# Altium schematic sheet Sandshark 3D - MCU - ATSAM3X8C.SchDoc
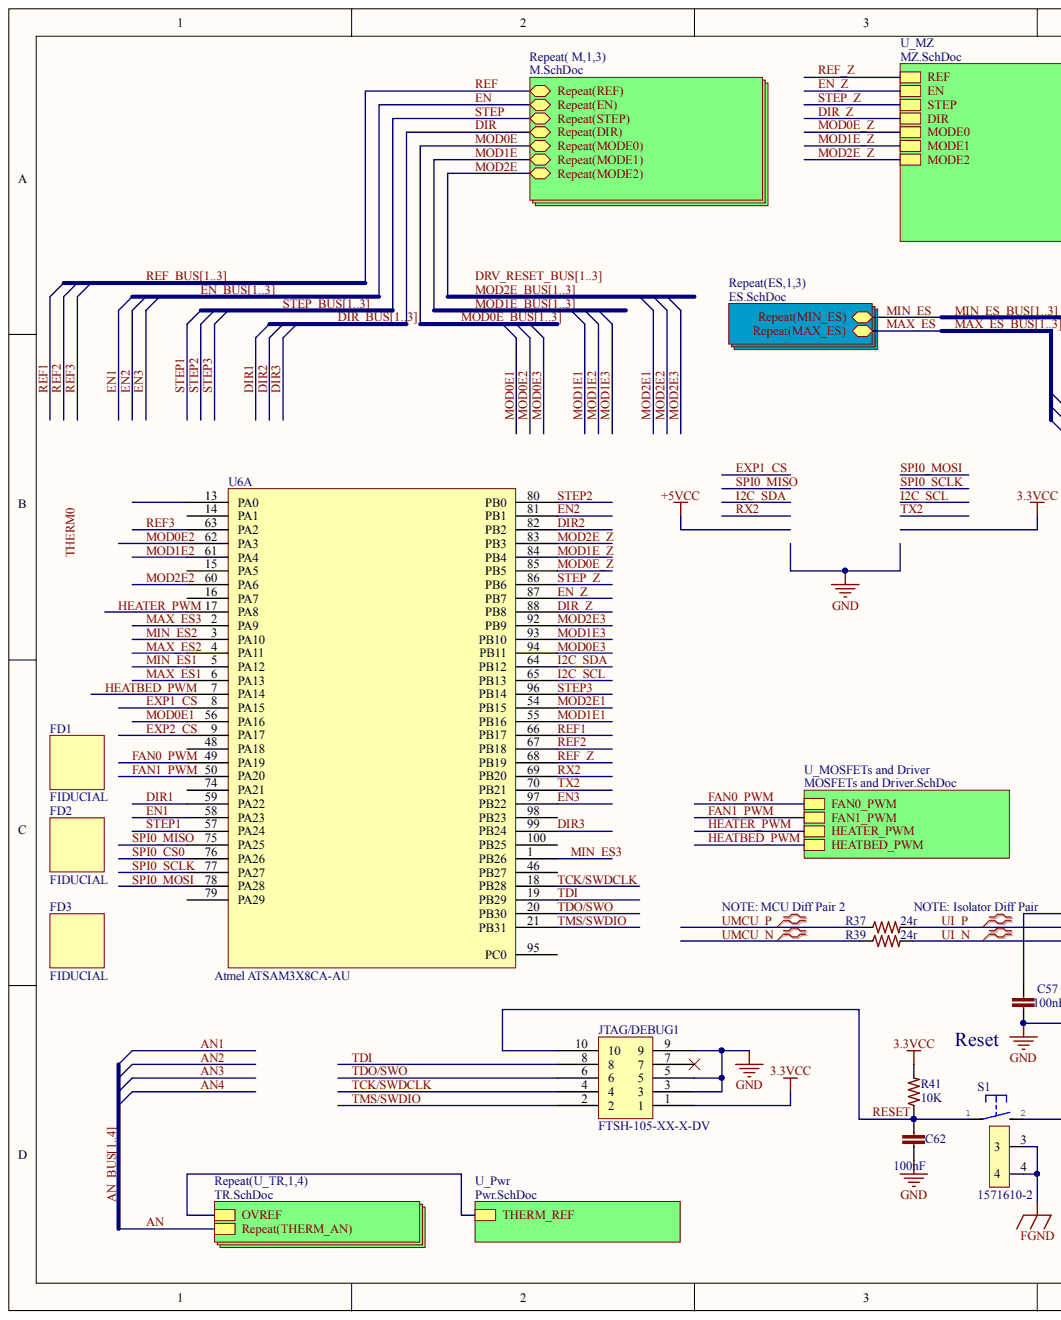
[diagram p0: Sandshark 3D - MCU - ATSAM3X8C.SchDoc, left]
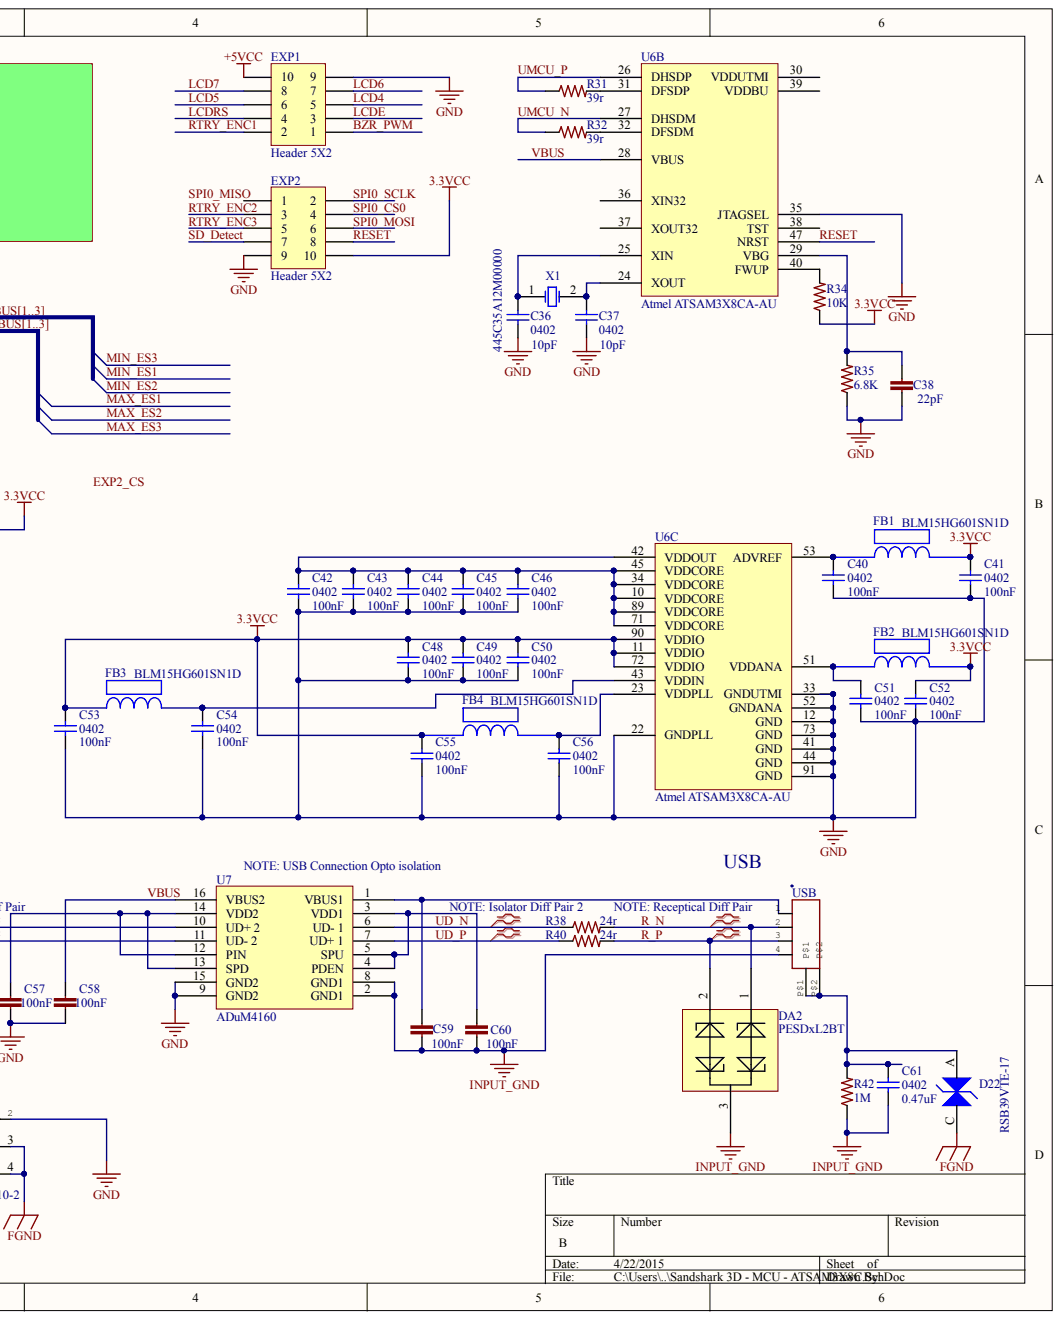
[diagram p1: Sandshark 3D - MCU - ATSAM3X8C.SchDoc, right]

## Parts
C36  0402  value 10pF  [C0402]
C37  0402  value 10pF  [C0402]
C38  22pF  [C0402]
C40  0402  value 100nF  [C0402]
C41  0402  value 100nF  [C0402]
C42  0402  value 100nF  [C0402]
C43  0402  value 100nF  [C0402]
C44  0402  value 100nF  [C0402]
C45  0402  value 100nF  [C0402]
C46  0402  value 100nF  [C0402]
C48  0402  value 100nF  [C0402]
C49  0402  value 100nF  [C0402]
C50  0402  value 100nF  [C0402]
C51  0402  value 100nF  [C0402]
C52  0402  value 100nF  [C0402]
C53  0402  value 100nF  [C0402]
C54  0402  value 100nF  [C0402]
C55  0402  value 100nF  [C0402]
C56  0402  value 100nF  [C0402]
C57  100nF  [C0402]
C58  100nF  [C0402]
C59  100nF  [C0402]
C60  100nF  [C0402]
C61  0402  value 0.47uF  [C0402]
C62  100nF  [C0402]
D22  RSB39VTE-17  [SOD323-R]
DA2  PESDxL2BT  [SOT95P230X110-3N]
EXP1  Header 5X2  [HDR2X5]
EXP2  Header 5X2  [HDR2X5]
FB1  BLM15HG601SN1D  [BLM18PG121SN1D]
FB2  BLM15HG601SN1D  [BLM18PG121SN1D]
FB3  BLM15HG601SN1D  [BLM18PG121SN1D]
FB4  BLM15HG601SN1D  [BLM18PG121SN1D]
FD1  FIDUCIAL  [FIDUCIAL-1X2]
FD2  FIDUCIAL  [FIDUCIAL-1X2]
FD3  FIDUCIAL  [FIDUCIAL-1X2]
JTAG/DEBUG1  FTSH-105-XX-X-DV  [FTSH-105-XX-X-DV]
R31  39r  [R0402]
R32  39r  [R0402]
R34  10K  [R0603]
R35  6.8K  [R0402]
R37  24r  [R0603]
R38  24r  [R0603]
R39  24r  [R0603]
R40  24r  [R0603]
R41  10K  [R0603]
R42  1M  [R0402]
S1  1571610-2  [B3F-31XX]
U6  Atmel ATSAM3X8CA-AU  Manufacture: Atmel  Manufacture Part #: ATSAM3X8CA-AU  [ATSAM3X8CA-AU TQFP100]  (parts A,B,C)
U7  ADuM4160  [ADUM4160BRWZ-RL]
USB  USB-B  [PN61729]  (value not drawn)
X1  445C35A12M00000  [445C35A12M00000]

## Nets
3.3VCC: C41.2 C48.2 C49.2 C50.2 C52.2 C53.2 C55.2 EXP2.10 FB1.2 FB2.2 FB3.1 FB4.1 JTAG/DEBUG1.1 R34.1 R41.2 U6.11(VDDIO) U6.72(VDDIO) U6.90(VDDIO)
+5VCC: EXP1.10
FGND: D22.C S1.3 S1.4
GND: C36.1 C37.1 C38.2 C40.1 C41.1 C42.1 C43.1 C44.1 C45.1 C46.1 C48.1 C49.1 C50.1 C51.1 C52.1 C53.1 C54.1 C55.1 C56.1 C57.2 C58.2 C62.2 EXP1.9 EXP2.9 JTAG/DEBUG1.3 JTAG/DEBUG1.5 JTAG/DEBUG1.9 R35.1 S1.2 U6.12(GND) U6.22(GNDPLL) U6.33(GNDUTMI) U6.35(JTAGSEL) U6.41(GND) U6.44(GND) U6.52(GNDANA) U6.73(GND) U6.91(GND) U7.9(GND2) U7.15(GND2)
INPUT_GND: C59.2 C60.2 C61.1 DA2.3 R42.1 U7.2(GND1) U7.8(GND1) USB.4
BZR_PWM: EXP1.1
DIR1: U6.59(PA22)
DIR2: U6.82(PB2)
DIR3: U6.99(PB24)
DIR_Z: U6.88(PB8)
EN1: U6.58(PA23)
EN2: U6.81(PB1)
EN3: U6.97(PB22)
EN_Z: U6.87(PB7)
EXP1_CS: U6.8(PA15)
EXP2_CS: U6.9(PA17)
FAN0_PWM: U6.49(PA19)
FAN1_PWM: U6.50(PA20)
HEATBED_PWM: U6.7(PA14)
HEATER_PWM: U6.17(PA8)
I2C_SCL: U6.65(PB13)
I2C_SDA: U6.64(PB12)
LCD4: EXP1.5
LCD5: EXP1.6
LCD6: EXP1.7
LCD7: EXP1.8
LCDE: EXP1.3
LCDRS: EXP1.4
MAX_ES1: U6.6(PA13)
MAX_ES2: U6.4(PA11)
MAX_ES3: U6.2(PA9)
MIN_ES1: U6.5(PA12)
MIN_ES2: U6.3(PA10)
MIN_ES3: U6.1(PB26)
MOD0E1: U6.56(PA16)
MOD0E2: U6.62(PA3)
MOD0E3: U6.94(PB11)
MOD0E_Z: U6.85(PB5)
MOD1E1: U6.55(PB16)
MOD1E2: U6.61(PA4)
MOD1E3: U6.93(PB10)
MOD1E_Z: U6.84(PB4)
MOD2E1: U6.54(PB15)
MOD2E2: U6.60(PA6)
MOD2E3: U6.92(PB9)
MOD2E_Z: U6.83(PB3)
R_N: DA2.1 R38.2 USB.2
R_P: DA2.2 R40.2 USB.3
REF1: U6.66(PB17)
REF2: U6.67(PB18)
REF3: U6.63(PA2)
REF_Z: U6.68(PB19)
RESET: C62.1 EXP2.8 JTAG/DEBUG1.10 R41.1 S1.1 U6.47(NRST)
RTRY_ENC1: EXP1.2
RTRY_ENC2: EXP2.3
RTRY_ENC3: EXP2.5
RX2: U6.69(PB20)
SD_DETECT: EXP2.7
SPI0_CS0: EXP2.4 U6.76(PA26)
SPI0_MISO: U6.75(PA25)
SPI0_MOSI: EXP2.6 U6.78(PA28)
SPI0_SCLK: EXP2.2 U6.77(PA27)
STEP1: U6.57(PA24)
STEP2: U6.80(PB0)
STEP3: U6.96(PB14)
STEP_Z: U6.86(PB6)
TCK/SWDCLK: JTAG/DEBUG1.4 U6.18(PB28)
TDI: JTAG/DEBUG1.8 U6.19(PB29)
TDO/SWO: JTAG/DEBUG1.6 U6.20(PB30)
TMS/SWDIO: JTAG/DEBUG1.2 U6.21(PB31)
TX2: U6.70(PB21)
UD_N: R38.1 U7.6(UD-_1)
UD_P: R40.1 U7.7(UD+_1)
UI_N: R39.2 U7.11(UD-_2)
UI_P: R37.2 U7.10(UD+_2)
UMCU_N: R32.1 R39.1 U6.27(DHSDM)
UMCU_P: R31.1 R37.1 U6.26(DHSDP)
VBUS: C58.1 U6.28(VBUS) U7.16(VBUS2)
NetC36_2: C36.2 U6.25(XIN) X1.1
NetC37_2: C37.2 U6.24(XOUT) X1.2
NetC38_1: C38.1 R35.2 U6.29(VBG)
NetC40_2: C40.2 FB1.1 U6.53(ADVREF)
NetC42_2: C42.2 C43.2 C44.2 C45.2 C46.2 U6.10(VDDCORE) U6.34(VDDCORE) U6.42(VDDOUT) U6.45(VDDCORE) U6.71(VDDCORE) U6.89(VDDCORE)
NetC51_2: C51.2 FB2.1 U6.51(VDDANA)
NetC54_2: C54.2 FB3.2 U6.43(VDDIN)
NetC56_2: C56.2 FB4.2 U6.23(VDDPLL)
NetC57_1: C57.1 U7.12(PIN) U7.13(SPD) U7.14(VDD2)
NetC59_1: C59.1 U7.1(VBUS1) USB.1
NetC60_1: C60.1 U7.3(VDD1) U7.4(PDEN) U7.5(SPU)
NetC61_2: C61.2 D22.A R42.2 USB.P$1 USB.P$2
NetEXP2_1: EXP2.1
NetJTAG/DEBUG1_7: JTAG/DEBUG1.7
NetR31_2: R31.2 U6.31(DFSDP)
NetR32_2: R32.2 U6.32(DFSDM)
NetR34_2: R34.2 U6.40(FWUP)
NetU6_13: U6.13(PA0)
NetU6_14: U6.14(PA1)
NetU6_15: U6.15(PA5)
NetU6_16: U6.16(PA7)
NetU6_30: U6.30(VDDUTMI)
NetU6_36: U6.36(XIN32)
NetU6_37: U6.37(XOUT32)
NetU6_38: U6.38(TST)
NetU6_39: U6.39(VDDBU)
NetU6_46: U6.46(PB27)
NetU6_48: U6.48(PA18)
NetU6_74: U6.74(PA21)
NetU6_79: U6.79(PA29)
NetU6_95: U6.95(PC0)
NetU6_98: U6.98(PB23)
NetU6_100: U6.100(PB25)
AN1:
AN2:
AN3:
AN4:
AN_BUS1:  [also labelled AN_BUS]
AN_BUS2:  [also labelled AN_BUS]
AN_BUS3:  [also labelled AN_BUS]
AN_BUS4:  [also labelled AN_BUS]
DIR_BUS1:  [also labelled DIR_BUS]
DIR_BUS2:  [also labelled DIR_BUS]
DIR_BUS3:  [also labelled DIR_BUS]
DRV_RESET_BUS1:  [also labelled DRV_RESET_BUS]
DRV_RESET_BUS2:  [also labelled DRV_RESET_BUS]
DRV_RESET_BUS3:  [also labelled DRV_RESET_BUS]
EN_BUS1:  [also labelled EN_BUS]
EN_BUS2:  [also labelled EN_BUS]
EN_BUS3:  [also labelled EN_BUS]
MAX_ES_BUS1:  [also labelled MAX_ES_BUS]
MAX_ES_BUS2:  [also labelled MAX_ES_BUS]
MAX_ES_BUS3:  [also labelled MAX_ES_BUS]
MIN_ES_BUS1:  [also labelled MIN_ES_BUS]
MIN_ES_BUS2:  [also labelled MIN_ES_BUS]
MIN_ES_BUS3:  [also labelled MIN_ES_BUS]
MOD0E_BUS1:  [also labelled MOD0E_BUS]
MOD0E_BUS2:  [also labelled MOD0E_BUS]
MOD0E_BUS3:  [also labelled MOD0E_BUS]
MOD1E_BUS1:  [also labelled MOD1E_BUS]
MOD1E_BUS2:  [also labelled MOD1E_BUS]
MOD1E_BUS3:  [also labelled MOD1E_BUS]
MOD2E_BUS1:  [also labelled MOD2E_BUS]
MOD2E_BUS2:  [also labelled MOD2E_BUS]
MOD2E_BUS3:  [also labelled MOD2E_BUS]
REF_BUS1:  [also labelled REF_BUS]
REF_BUS2:  [also labelled REF_BUS]
REF_BUS3:  [also labelled REF_BUS]
STEP_BUS1:  [also labelled STEP_BUS]
STEP_BUS2:  [also labelled STEP_BUS]
STEP_BUS3:  [also labelled STEP_BUS]
THERM0:
THERM_REF:

## Library data of the parts
C36 C37 = CL05C100JB5NNNC: CAP CER 10PF 50V 5% NP0 0402; MFR: Samsung; Tolerance: 10%; Voltage: 50VDC; Component Kind: Standard; Component Type: Chip Capacitor; Datasheet: http://www.samsungsem.com/kr/front/downloadcms.do?path=/kr/support/product-search/mlcc/__icsFiles/afieldfile/2014/11/05&fileName=S_CL05C100JB5NNNC.pdf; Height: 0.55mm; LatestRevisionDate: 10 - Dec - 2014; LatestRevisionNote: Ultimachine Footprint Added; Library Name: Tigershark3D.SchLib; MFR Part #: CL05C100JB5NNNC; Mounting Type: SMT 0402; PackageReference: 0402; Part Description: CAP CER 10PF 50V 5% NP0 0402; Published: 10 - Dec - 2014; Signal Integrity: Cap Semi; Simulation: CAP; Publisher: Ultimachine
C38 = 04025A220JAT2A: CAP CER 0402 22pF 50V 5% C0G; LastRevisionNote: ImportComponents; Component Kind: Standard; LastRevisionDate: 23-Apr-2014; Library Name: 3Delight.SCHLIB; ManufacturerPartNumber: 04025A220JAT2A; Published: 23-Apr-2014; Signal Integrity: Model Name; Simulation: Model Name; VALUE: 22pF; Publisher: UltiMachine
C40-C46 C48-C56 = GRM155R71C104KA88D: CAP CER 0.1UF (100nF) 16V 10% X7R 0402; MFR: Murata; Part Description: CAP CER 0.1UF 16V 10% X7R 0402; Component Kind: Standard; Component Type: Chip Capacitor; Datasheet: http://psearch.murata.com/capacitor/product/GRM155R71C104KA88%23.pdf; Height: 0.5 ±0.05mm; LatestRevisionDate: 10 - Dec - 2014; LatestRevisionNote: Ultimachine Footprint Added; Library Name: Tigershark3D.SchLib; MFR Part #: GRM155R71C104KA88D; Mounting Type: SMT 0402; PackageReference: 0402; Published: 10 - Dec - 2014; Signal Integrity: Cap Semi; Simulation: CAP; Tolerance: 10%; Voltage: 16v; Publisher: Ultimachine
C57-C60 C62 = GRM155R71C104KA88D: CAP CER 0402 100nF 16V 10% X7R; LastRevisionNote: ImportComponents; Component Kind: Standard; LastRevisionDate: 23-Apr-2014; Library Name: 3Delight.SCHLIB; ManufacturerPartNumber: GRM155R71C104KA88D; Published: 23-Apr-2014; Signal Integrity: Model Name; Simulation: Model Name; VALUE: 100nF; Publisher: UltiMachine
C61 = GRM155R60J474KE19D: 0.47uF 6.3v C0402 - GRM155R60J474KE19D; MFR: Murata; Part Description: CAP CER 0.47UF 6.3V 10% X5R 0402; Tolerance: 10%; Voltage: 6.3VDC; Component Kind: Standard; Component Type: Chip Capacitor; Datasheet: http://search.murata.co.jp/Ceramy/image/img/A01X/G101/ENG/GRM155R60J474KE19-01.pdf; Height: 0.5 ±0.05mm; LatestRevisionDate: 10 - Dec - 2014; LatestRevisionNote: Ultimachine Footprint Added; Library Name: Tigershark3D.SchLib; MFR Part #: GRM155R60J474KE19D; Mounting Type: SMT 0402; PackageReference: 0402; Published: 10 - Dec - 2014; Signal Integrity: Cap Semi; Simulation: CAP; Publisher: Ultimachine
D22 = RSB39VTE-17: DIODE TVS; Component Kind: Standard; Library Name: Tigershark3D.SchLib
DA2 = PESDxL2BT: PESDxL2BT TVS Diode Array 2x; Component Kind: Standard; Library Name: Tigershark3D.SchLib
EXP1 EXP2 = Header 5X2: Header, 5-Pin, Dual row; Component Kind: Standard; LatestRevisionDate: 17-Jul-2002; LatestRevisionNote: Re-released for DXP Platform.; Library Name: Miscellaneous Connectors.IntLib; Signal Integrity: Connector; Publisher: Altium Limited
JTAG/DEBUG1 = ARM Cortex JTAG-DEBUG Header: Component Kind: Standard; Library Name: Adams Altium Library.SchLib
R31 R32 = ERJ-2RKF39R0X: RES SMD 39 OHM 1% 1/10W 0402; Manufacturer: Panasonic; LastRevisionNote: ImportComponents; Component Kind: Standard; Height: 0.4mm; LastRevisionDate: 23-Apr-2014; Library Name: Tigershark3D.SchLib; ManufacturerPartNumber: ERJ-2RKF39R0X; Published: 23-Apr-2014; Signal Integrity: Model Name; Simulation: Model Name; VALUE: 39r; Publisher: UltiMachine
R34 R41 = ERJ-3EKF1002V: RES 0603 10K 100mW 1%; LastRevisionNote: ImportComponents; Component Kind: Standard; LastRevisionDate: 23-Apr-2014; ManufacturerPartNumber: ERJ-3EKF1002V; Published: 23-Apr-2014; Signal Integrity: Model Name; Simulation: Model Name; VALUE: 10K; Publisher: UltiMachine
  R34: Library Name: Tigershark3D.SchLib
  R41: Library Name: 3Delight.SCHLIB
R35 = CRCW04026K80FKED: RES SMD 6.8K OHM 1% 1/16W 0402; Manufacturer: Vishay Dale; LastRevisionNote: ImportComponents; Component Kind: Standard; Height: 0.4mm; LastRevisionDate: 23-Apr-2014; Library Name: Tigershark3D.SchLib; ManufacturerPartNumber: CRCW04026K80FKED; Published: 23-Apr-2014; Signal Integrity: Model Name; Simulation: Model Name; VALUE: 6.8K; Publisher: UltiMachine
R37-R40 = RC1608F240CS: RES SMD 24 OHM 1% 1/10W 0603; Manufacturer: Samsung; ManufacturerPartNumber: RC0603FR-07100KL; LastRevisionNote: ImportComponents; Component Kind: Standard; LastRevisionDate: 23-Apr-2014; Library Name: Tigershark3D.SchLib; PDF: http://www.samsungsem.com/global/support/library/product-catalog/__icsFiles/afieldfile/2015/01/12/CHIP_RESISTOR_150112_1.pdf; Published: 23-Apr-2014; Signal Integrity: Model Name; Simulation: Model Name; VALUE: 24r; Publisher: UltiMachine
R42 = ERJ2GEJ105X: RES 0402 1M 100mW 5%; LastRevisionNote: ImportComponents; Component Kind: Standard; LastRevisionDate: 23-Apr-2014; Library Name: Tigershark3D.SchLib; ManufacturerPartNumber: ERJ2GEJ105X; Published: 23-Apr-2014; Signal Integrity: Model Name; Simulation: Model Name; VALUE: 1M; Publisher: UltiMachine
S1 = 1571610-2_1: SWTCH Momentary Washable; Manufacturer: TE; Manufacturer: Omron; LastRevisionNote: Import; Component Kind: Standard; ComponentLink1Description: Datasheet for B3F-31XX; ComponentLink1URL: http://www.te.com/commerce/DocumentDelivery/DDEController?Action=srchrtrv&DocNm=1571610&DocType=Customer+Drawing&DocLang=English; LastRevisionDate: 23-Apr-2014; ManufacturerPartNumber: 1571610-2; Published: 23-Apr-2014; Supplier 1: Mouser; Supplier Part Number 1: 506-1571610-2; Library Name: ZMBo Schematic Library.SchLib; Publisher: UltiMachine
U6 = ATML-ATSAM3X8CA-AU-LQFP-100: Atmel ARM Cortex M3 MCU ATSAM3X8CA-AU; Component Kind: Standard; Datasheet: http://www.atmel.com/Images/doc11057s.pdf; Library Name: Tigershark3D.SchLib; Mounting Type: SMT TQFP; Package Type: TQFP100; Type: ARM Cortex M3 Microcontroller
U7 = ADuM4160: ADI iCoupler - USB 2.0 Galvanic Isolator; Component Kind: Standard; Library Name: Tigershark3D.SchLib
USB = USB-B: USB connector B PTH; LastRevisionNote: ImportComponents; Component Kind: Standard; LastRevisionDate: 23-Apr-2014; Library Name: 3Delight.SCHLIB; Published: 23-Apr-2014; Publisher: UltiMachine
X1 = XTAL: Crystal Oscillator; Component Kind: Standard; LatestRevisionDate: 17-Jul-2002; LatestRevisionNote: Re-released for DXP Platform.; Library Name: Miscellaneous Devices.IntLib; PackageDescription: Crystal, Thru-Hole; 2 Leads; Body 3.1 x 8.2 mm (Dia.xH); PackageReference: R38; Published: 8-Jun-2000; Simulation: XTAL; Publisher: Altium Limited
FB1-FB4 = BLM15HG601SN1D: Component Kind: Standard; Description: BLM15HG601SN1D; Library Name: AHD Part Library.SchLib
FD1-FD3 = FIDUCIAL: Component Kind: Standard; Library Name: ZMBo Schematic Library.SchLib
